# Altium schematic sheet main.SchDoc(main)
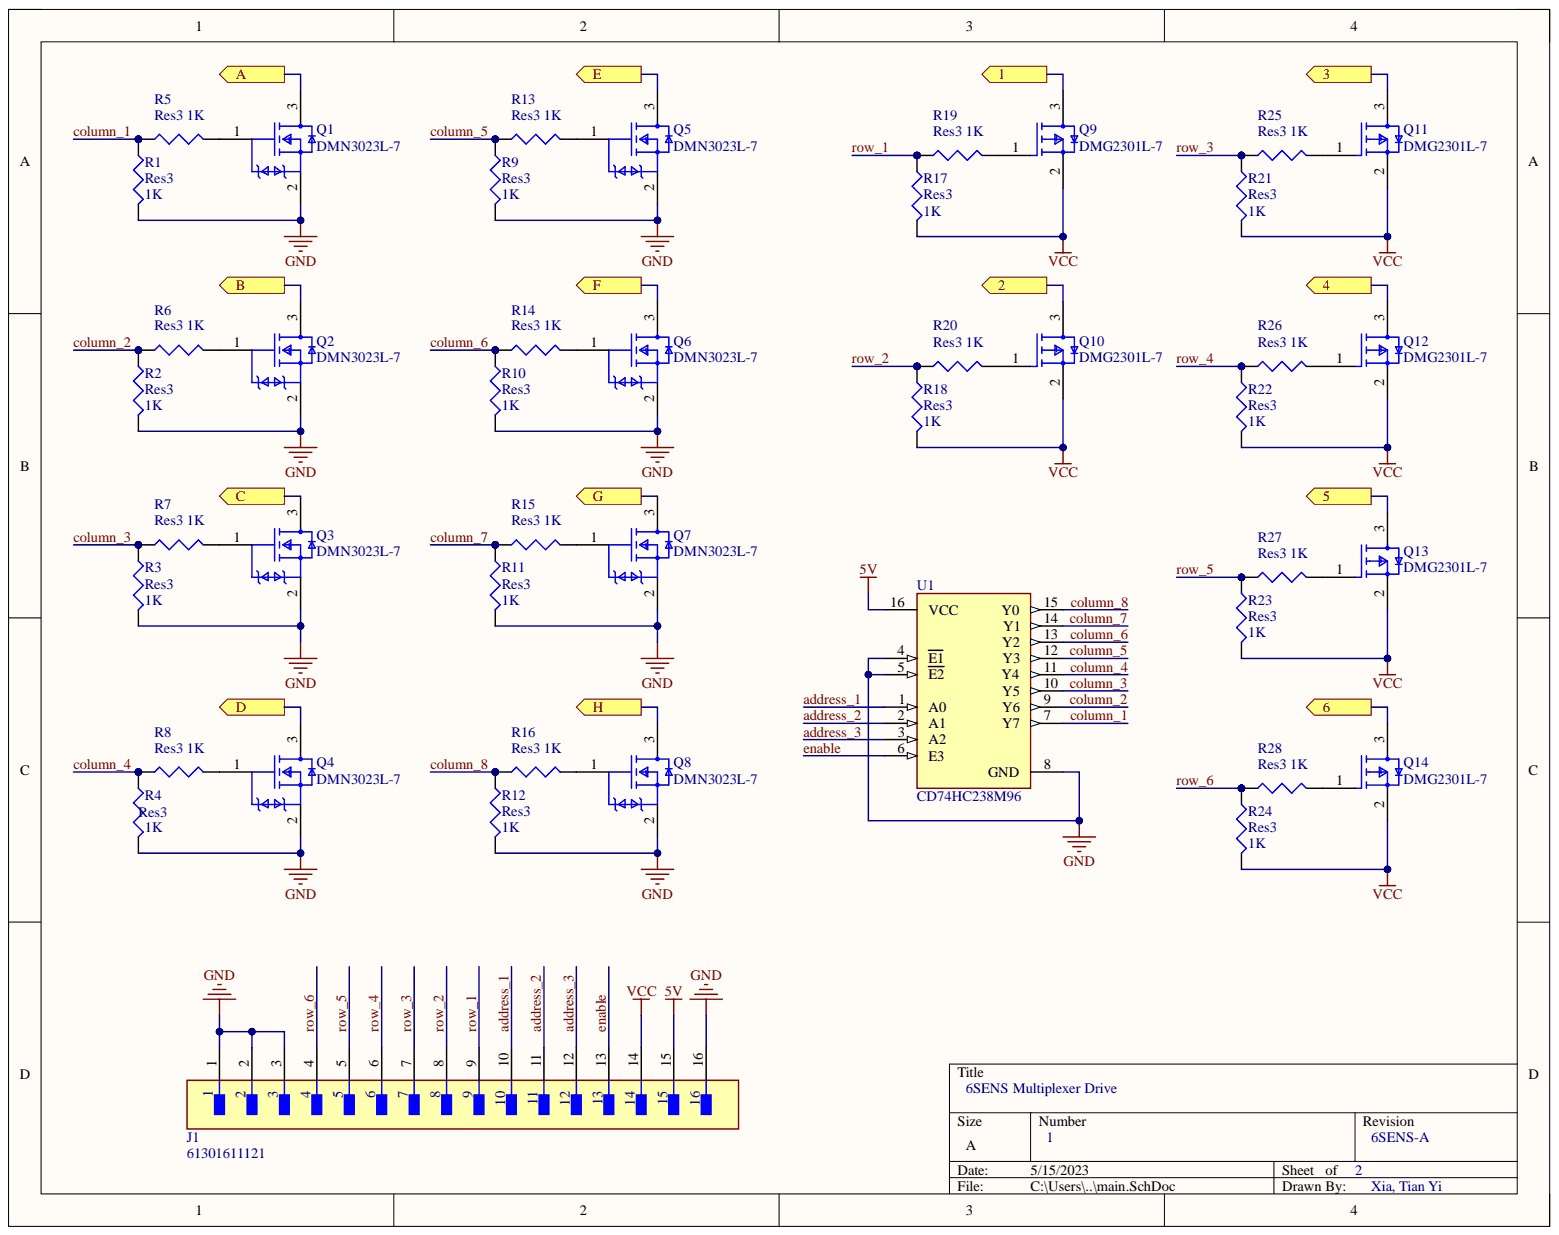
[diagram p0: main.SchDoc]

## Parts
J1  61301611121  [FP-61301611121-MFG]
Q1  DMN3023L-7  [FP-SOT23-MFG]
Q2  DMN3023L-7  [FP-SOT23-MFG]
Q3  DMN3023L-7  [FP-SOT23-MFG]
Q4  DMN3023L-7  [FP-SOT23-MFG]
Q5  DMN3023L-7  [FP-SOT23-MFG]
Q6  DMN3023L-7  [FP-SOT23-MFG]
Q7  DMN3023L-7  [FP-SOT23-MFG]
Q8  DMN3023L-7  [FP-SOT23-MFG]
Q9  DMG2301L-7  [FP-SOT23-MFG]
Q10  DMG2301L-7  [FP-SOT23-MFG]
Q11  DMG2301L-7  [FP-SOT23-MFG]
Q12  DMG2301L-7  [FP-SOT23-MFG]
Q13  DMG2301L-7  [FP-SOT23-MFG]
Q14  DMG2301L-7  [FP-SOT23-MFG]
R1  Res3  value 1K  [J1-0603]
R2  Res3  value 1K  [J1-0603]
R3  Res3  value 1K  [J1-0603]
R4  Res3  value 1K  [J1-0603]
R5  Res3  value 1K  [J1-0603]
R6  Res3  value 1K  [J1-0603]
R7  Res3  value 1K  [J1-0603]
R8  Res3  value 1K  [J1-0603]
R9  Res3  value 1K  [J1-0603]
R10  Res3  value 1K  [J1-0603]
R11  Res3  value 1K  [J1-0603]
R12  Res3  value 1K  [J1-0603]
R13  Res3  value 1K  [J1-0603]
R14  Res3  value 1K  [J1-0603]
R15  Res3  value 1K  [J1-0603]
R16  Res3  value 1K  [J1-0603]
R17  Res3  value 1K  [J1-0603]
R18  Res3  value 1K  [J1-0603]
R19  Res3  value 1K  [J1-0603]
R20  Res3  value 1K  [J1-0603]
R21  Res3  value 1K  [J1-0603]
R22  Res3  value 1K  [J1-0603]
R23  Res3  value 1K  [J1-0603]
R24  Res3  value 1K  [J1-0603]
R25  Res3  value 1K  [J1-0603]
R26  Res3  value 1K  [J1-0603]
R27  Res3  value 1K  [J1-0603]
R28  Res3  value 1K  [J1-0603]
U1  CD74HC238M96  [FP-D0016A-MFG]

## Ports
1, 2, 3, 4, 5, 6, A, B, C, D, E, F, G, H

## Nets
5V: J1.15 U1.16(VCC)
GND: J1.1 J1.2 J1.3 J1.16 Q1.2 Q2.2 Q3.2 Q4.2 Q5.2 Q6.2 Q7.2 Q8.2 R1.2 R2.2 R3.2 R4.2 R9.2 R10.2 R11.2 R12.2 U1.4(E1) U1.5(E2) U1.8(GND)
VCC: J1.14 Q9.2 Q10.2 Q11.2 Q12.2 Q13.2 Q14.2 R17.2 R18.2 R21.2 R22.2 R23.2 R24.2
1: Q9.3 ; port 1
2: Q10.3 ; port 2
3: Q11.3 ; port 3
4: Q12.3 ; port 4
5: Q13.3 ; port 5
6: Q14.3 ; port 6
A: Q1.3 ; port A
address_1: J1.10 U1.1(A0)
address_2: J1.11 U1.2(A1)
address_3: J1.12 U1.3(A2)
B: Q2.3 ; port B
C: Q3.3 ; port C
column_1: R1.1 R5.1 U1.7(Y7)
column_2: R2.1 R6.1 U1.9(Y6)
column_3: R3.1 R7.1 U1.10(Y5)
column_4: R4.1 R8.1 U1.11(Y4)
column_5: R9.1 R13.1 U1.12(Y3)
column_6: R10.1 R14.1 U1.13(Y2)
column_7: R11.1 R15.1 U1.14(Y1)
column_8: R12.1 R16.1 U1.15(Y0)
D: Q4.3 ; port D
E: Q5.3 ; port E
enable: J1.13 U1.6(E3)
F: Q6.3 ; port F
G: Q7.3 ; port G
H: Q8.3 ; port H
row_1: J1.9 R17.1 R19.1
row_2: J1.8 R18.1 R20.1
row_3: J1.7 R21.1 R25.1
row_4: J1.6 R22.1 R26.1
row_5: J1.5 R23.1 R27.1
row_6: J1.4 R24.1 R28.1
NetQ1_1: Q1.1 R5.2
NetQ2_1: Q2.1 R6.2
NetQ3_1: Q3.1 R7.2
NetQ4_1: Q4.1 R8.2
NetQ5_1: Q5.1 R13.2
NetQ6_1: Q6.1 R14.2
NetQ7_1: Q7.1 R15.2
NetQ8_1: Q8.1 R16.2
NetQ9_1: Q9.1 R19.2
NetQ10_1: Q10.1 R20.2
NetQ11_1: Q11.1 R25.2
NetQ12_1: Q12.1 R26.2
NetQ13_1: Q13.1 R27.2
NetQ14_1: Q14.1 R28.2

## Library data of the parts
J1 = CMP-1502-01095-2: CONN HEADER VERT 16POS 2.54MM; Gender: Male; Number of Contacts: 16; Pitch: 0.1inch; Voltage Rating: 250V; Color: Black; Component Kind: Standard; Connector Type: Header; Contact Finish - Mating: GOLD; Contact Material: Copper Alloy; Contact Mating Length: 0.236inch; Contact Plating: Gold; Contact Shape: Square; Contact Type: Male Pin; Fastening Type: Pull; Flammability Rating: UL94 V-0; Insulation Color: Black; Insulation Material: Nylon; Library Name: Altium Content Vault; Max Operating Temperature: 105°C; Min Operating Temperature: -40°C; Mount: Through Hole; Number of Positions: 16; Number of Rows: 1; Packaging: Bulk; RoHS Compliant: Yes; Series: WR-PHD; Termination: Solder
Q1-Q8 = CMP-12801-000035-1: MOSFET N-CH 30V 6.2A SOT23; Manufacturer: Diodes Inc.; Automotive Grade: Grade 0; Depletion Mode: False; Drain To Source Resistance: 25mΩ; Gate Charge At Vgs: 18.4nC @ 10V; Gate To Source Voltage: 20V; Input Capacitace At Vds: 873pF @ 15V; Max Forward Diode Voltage: 1.2V; Max Junction Temp: +150°C; Number Of Channels: 1; Power Dissipation: 0.9W; Pulse Drain Current: 44A; Rthja Max: 97°C/W; Standoff Height: 0.013mm; Threshold Vgs Max: 1.8V; Threshold Vgs Min: 0.8V; Turn Off Delay Time: 231ns; Turn On Delay Time: 17ns; Automotive: Yes; Category: Trans; Component Kind: Standard; ComponentLink2Description: Datasheet; ComponentLink2URL: https://www.diodes.com/assets/Datasheets/DMN3023L.pdf; Continuous Drain Current: 6.2A; Device Class L1: Discrete Semiconductors; Device Class L2: Transistors; Device Class L3: MOSFETs; Digikey Description: MOSFET N-CH 30V 6.2A SOT23; Drain To Source Breakdown Voltage: 30V; Drain To Source Voltage: 30V; Footprint Url: https://www.diodes.com/assets/Package-Files/SOT23.pdf; Height: 1.1mm; Lead Free: Yes; Library Name: Altium Content Vault; Manufacturer Part Number: DMN3023L-7; Mouser Description: MOSFET N-Ch Enh Mode FET 30Vdss 20Vgss 51A; Number Of N Channels: 1; Octopart: https://octopart.com/dmn3023l-7-diodes+inc.-65294126; Package: SOT23; RoHS: TRUE; Temperature: -55°C to +150°C; Temperature Range High: +150°C; Temperature Range Low: -55°C
Q9-Q14 = CMP-12801-000065-1: MOSFET P-CH 20V 3A SOT23; Manufacturer: Diodes Inc.; Continuous Drain Current: -3A; Depletion Mode: False; Drain To Source Breakdown Voltage: -20V; Drain To Source Resistance: 120mΩ; Gate Charge At Vgs: 5.5nC @ -4.5V; Gate To Source Voltage: 8V; Input Capacitace At Vds: 476pF @ -10V; Max Forward Diode Voltage: -1.2V; Max Junction Temp: +150°C; Number Of Channels: 1; Power Dissipation: 1.5W; Pulse Drain Current: -10A; Rthja Max: 83°C/W; Standoff Height: 0.013mm; Threshold Vgs Min: -0.4V; Turn Off Delay Time: 30ns; Turn On Delay Time: 5ns; Automotive: No; Category: Trans; Component Kind: Standard; ComponentLink2Description: Datasheet; ComponentLink2URL: https://www.diodes.com/assets/Datasheets/DMG2301L.pdf; Device Class L1: Discrete Semiconductors; Device Class L2: Transistors; Device Class L3: MOSFETs; Digikey Description: MOSFET P-CH 20V 3A SOT23; Drain To Source Voltage: -20V; Footprint Url: https://www.diodes.com/assets/Package-Files/SOT23.pdf; Height: 1.1mm; Lead Free: Yes; Library Name: Altium Content Vault; Manufacturer Part Number: DMG2301L-7; Mouser Description: Single P-Channel 20 V 1.5 W 5.5 nC Silicon Surface Mount Mosfet - SOT-23; Number Of P Channels: 1; Octopart: https://octopart.com/dmg2301l-7-diodes+inc.-67250456; Package: SOT23; RoHS: TRUE; Temperature: -55°C to +150°C; Temperature Range High: +150°C; Temperature Range Low: -55°C; Threshold Vgs Max: -1.2V
R1-R28 = Res3: Resistor; Component Kind: Standard; LatestRevisionDate: 29-May-2009; LatestRevisionNote: IPC-7351 Footprint Added.; Library Name: Miscellaneous Devices.IntLib; PackageDescription: Chip Resistor; PackageReference: J1-0603; Published: 8-Jun-2000; Signal Integrity: Res; Simulation: RESISTOR; Publisher: Altium Limited
U1 = CMP-1633-00102-3: IC 3-8 DECODER/DEMUX HS 16-SOIC; Manufacturer: Texas Instruments; Max Junction Temp: +150°C; Max Supply Voltage: 6V; Min Supply Voltage: 2V; Number Of Circuits: 1; Number Of Inputs: 6; Number Of Outputs: 8; Propagation Delay: 13ns; Quiescent Current: 8uA; Standoff Height: 0.25mm; Ambient Temperature Range High: +125°C; Ambient Temperature Range Low: -55°C; Automotive: No; Category: IC; Component Kind: Standard; ComponentLink2Description: Datasheet; ComponentLink2URL: http://www.ti.com/lit/ds/symlink/cd74hc238.pdf; Device Class L1: Integrated Circuits (ICs); Device Class L2: Logic ICs; Device Class L3: Decoders; Digikey Description: IC 3-8 DECODER/DEMUX HS 16-SOIC; Height: 1.75mm; Ipc Land Pattern Name: SOIC127P600X175-16; Lead Free: Yes; Library Name: Altium Content Vault; Manufacturer Part Number: CD74HC238M96; Mouser Description: High Speed CMOS Logic 3-to-8 Line Decoder Demultiplexer Inverting and Non-Inverting 16-SOIC -55 to 125; Octopart: https://octopart.com/cd74hc238m96-texas+instruments-1365099; Package: D0016A; RoHS: TRUE; Temperature: -55°C to +125°C; Temperature Range High: +125°C; Temperature Range Low: -55°C
